# Altium schematic sheet Sheet1.SchDoc(Sheet1)
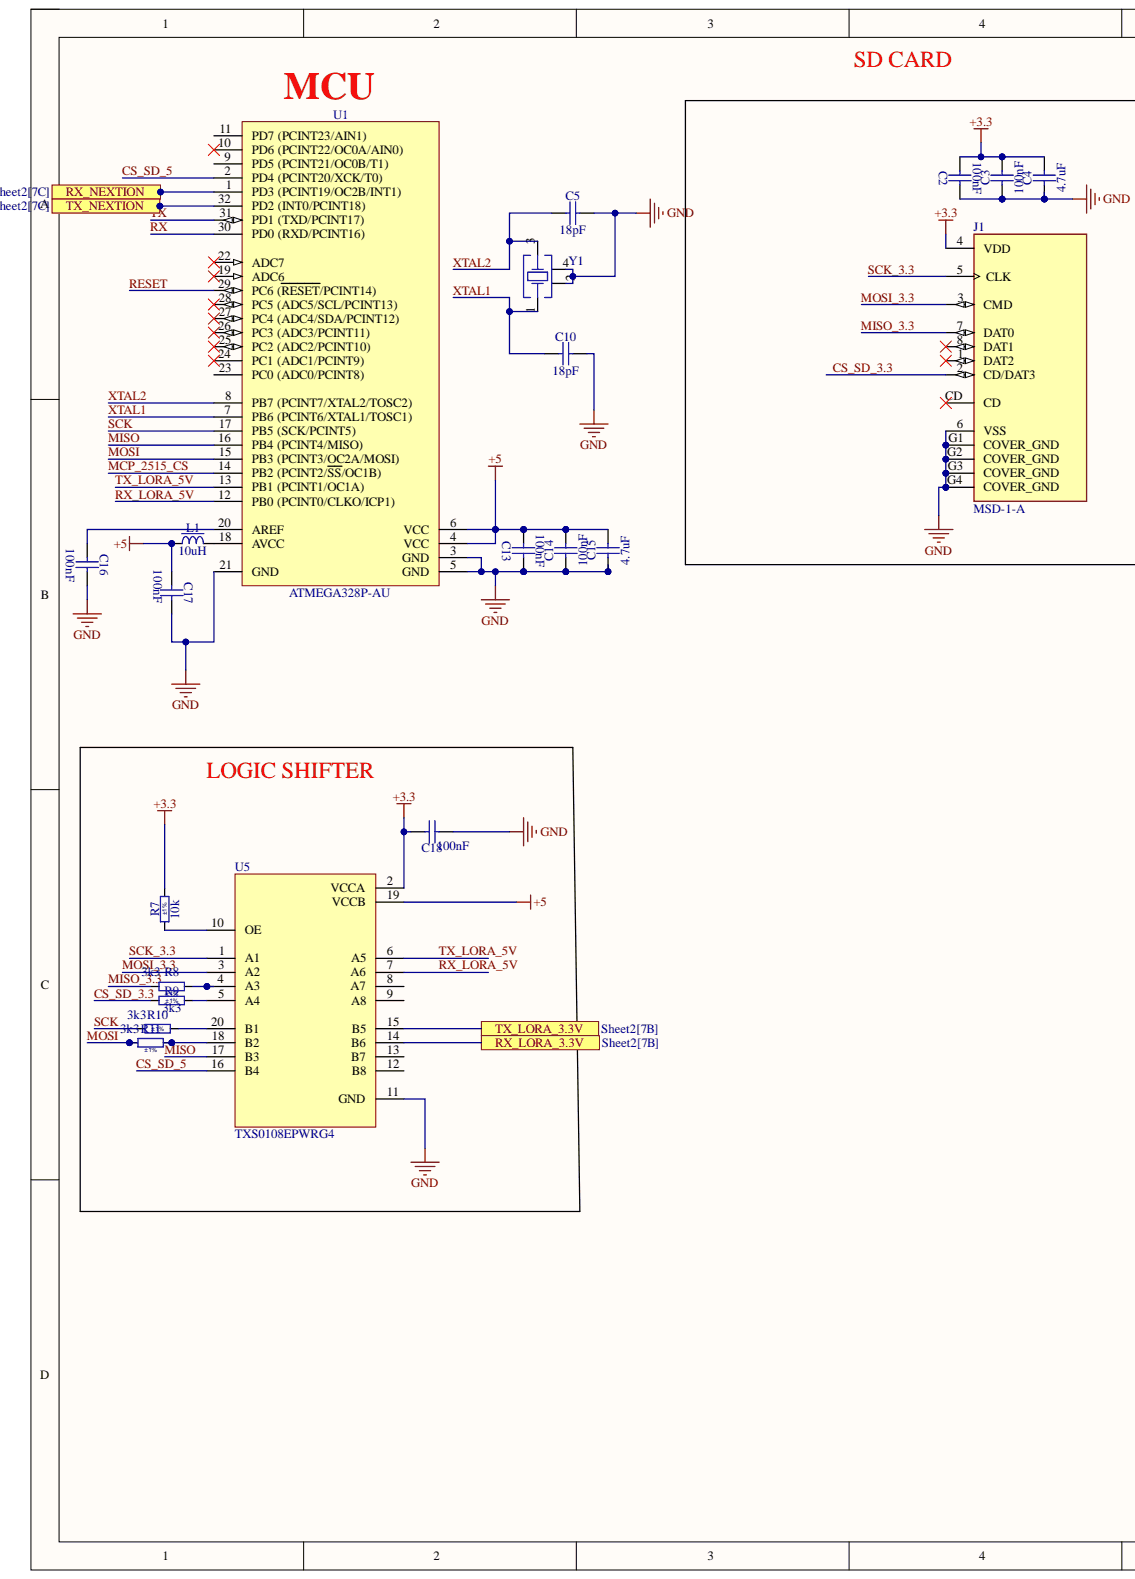
[diagram p0: Sheet1.SchDoc, left]
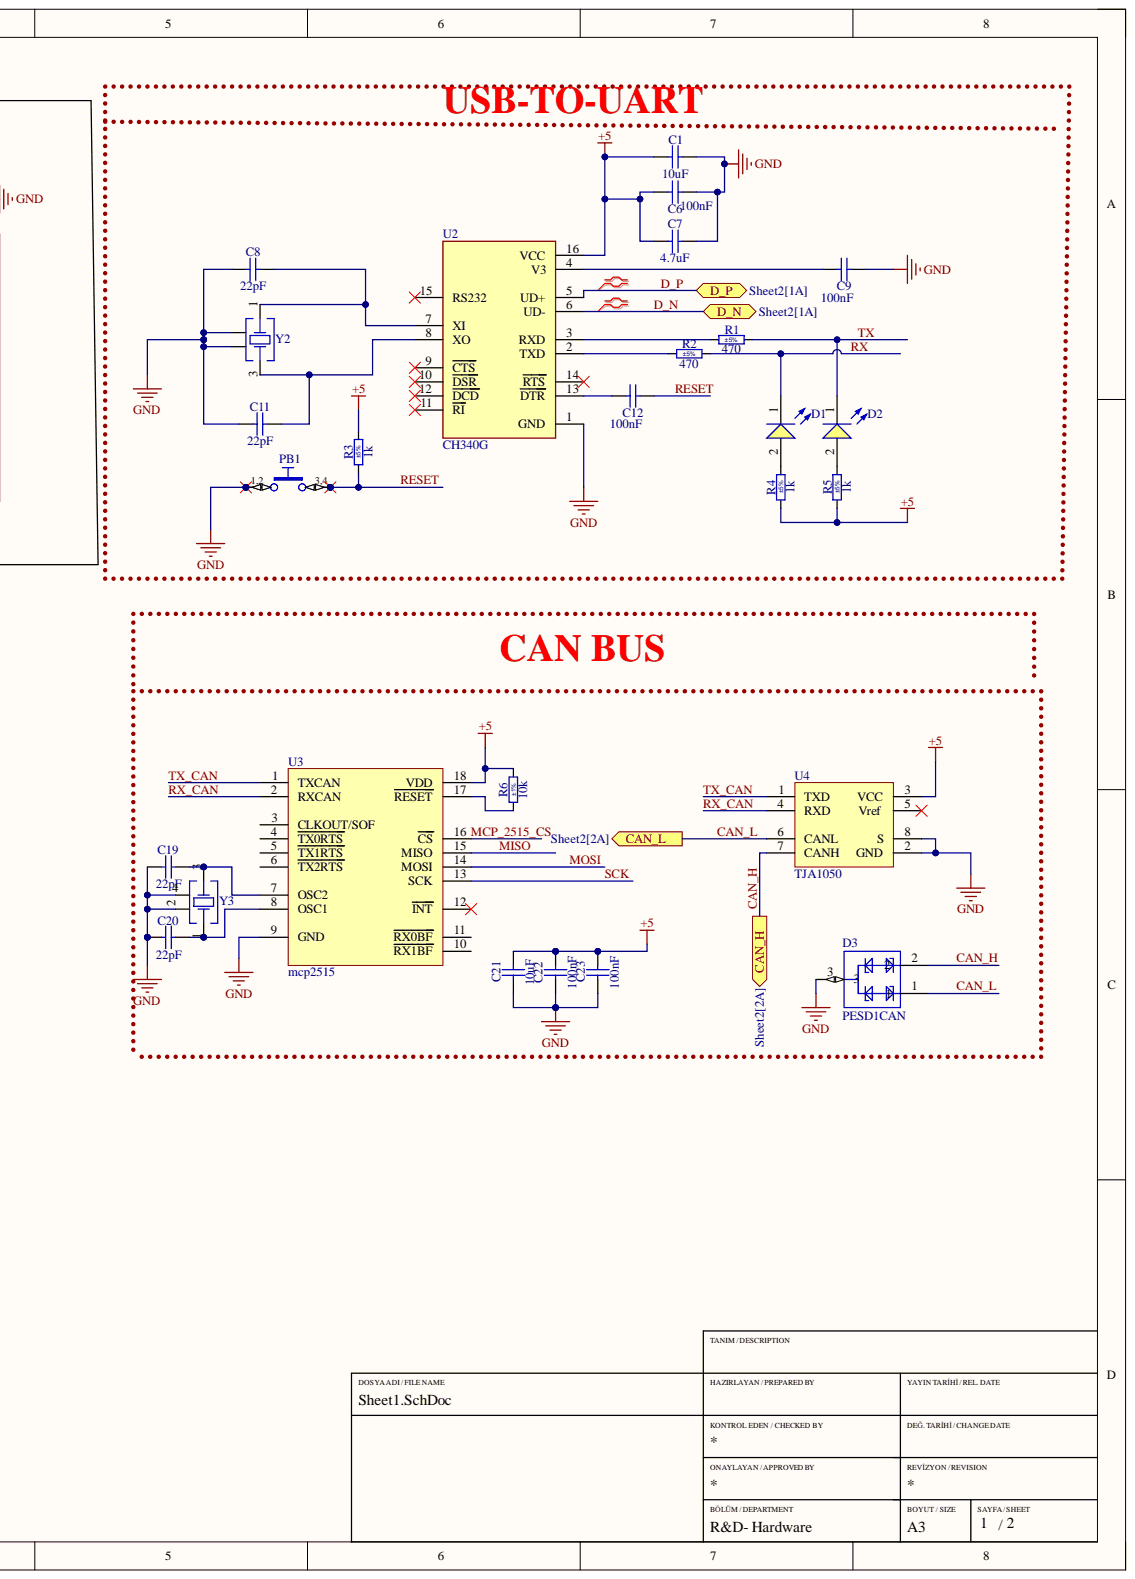
[diagram p1: Sheet1.SchDoc, right]

## Parts
C1  10uF  [CAPC2012X135N]
C2  100nF  [CAPC2012X135N]
C3  100nF  [CAPC2012X135N]
C4  4.7uF  [CAPC2012X135N]
C5  18pF  [CAPC2012X135N]
C6  100nF  [CAPC2012X135N]
C7  4.7uF  [CAPC2012X135N]
C8  22pF  [CAPC2012X135N]
C9  100nF  [CAPC2012X135N]
C10  18pF  [CAPC2012X135N]
C11  22pF  [CAPC2012X135N]
C12  100nF  [CAPC2012X135N]
C13  100nF  [CAPC2012X135N]
C14  100nF  [CAPC2012X135N]
C15  4.7uF  [CAPC2012X135N]
C16  100nF  [CAPC2012X135N]
C17  100nF  [CAPC2012X135N]
C18  100nF  [CAPC2012X135N]
C19  22pF  [CAPC2012X135N]
C20  22pF  [CAPC2012X135N]
C21  10uF  [CAPC2012X135N]
C22  100nF  [CAPC2012X135N]
C23  100nF  [CAPC2012X135N]
D1  Yellow, 2V, 140°  [WL-SMCW_0805_150080xx75000]  (value not drawn)
D2  Yellow, 2V, 140°  [WL-SMCW_0805_150080xx75000]  (value not drawn)
D3  PESD1CAN  [SOT23-3]
J1  MSD-1-A  [CUI_MSD-1-A]
L1  10uH  [INDC2014X145N]
PB1  Push Button  [PB_TYCO_FSM2JSMA]  (value not drawn)
R1  470  Tolerance: ±5%  [RESC2012X60N]
R2  470  Tolerance: ±5%  [RESC2012X60N]
R3  1k  Tolerance: ±5%  [RESC2012X70N]
R4  1k  Tolerance: ±5%  [RESC2012X60N]
R5  1k  Tolerance: ±5%  [RESC2012X60N]
R6  10k  Tolerance: ±1%  [RESC2012X60N]
R7  10k  Tolerance: ±1%  [RESC2012X60N]
R8  3k3  Tolerance: ±1%  [RESC2012X60N]
R9  3k3  Tolerance: ±1%  [RESC2012X60N]
R10  3k3  Tolerance: ±1%  [RESC2012X60N]
R11  3k3  Tolerance: ±1%  [RESC2012X60N]
U1  ATMEGA328P-AU  [QFP80P900X900X120-32N]
U2  CH340G  [SOIC-16]
U3  mcp2515  [SOIC-18 - duplicate]
U4  TJA1050  [SOIC-8]
U5  TXS0108EPWRG4  [SOP65P640X120-20N]
Y1  Load Capacitance: 18pF  [WE-XTAL_CFPX-104]  (hidden comment: 8MHz)
Y2  12MHz  [WE-XTAL_CFPX-104]  (value not drawn)
Y3  8MHz  [WE-XTAL_CFPX-104]  (value not drawn)

## Ports
CAN_H, CAN_L, D_N, D_P, RX_LORA_3.3V, RX_NEXTION, TX_LORA_3.3V, TX_NEXTION

## Nets
+3.3: C2.1 C3.2 C4.2 C18.1 J1.4(VDD) R7.2 U5.2(VCCA)
+5: C1.1 C6.1 C7.1 C13.1 C14.2 C15.2 C17.1 C21.2 C22.2 C23.2 L1.1 R3.2 R4.1 R5.1 R6.2 U1.4(VCC) U1.6(VCC) U2.16(VCC) U3.18(VDD) U4.3(VCC) U5.19(VCCB)
GND: C1.2 C2.2 C3.1 C4.1 C5.2 C6.2 C7.2 C8.1 C9.2 C10.2 C11.1 C13.2 C14.1 C15.1 C16.2 C17.2 C18.2 C19.1 C20.1 C21.1 C22.1 C23.1 D3.3 J1.6(VSS) J1.G1(COVER_GND) J1.G2(COVER_GND) J1.G3(COVER_GND) J1.G4(COVER_GND) PB1.1 PB1.2 U1.3(GND) U1.5(GND) U1.21(GND) U2.1(GND) U3.9(GND) U4.2(GND) U4.8(S) U5.11(GND) Y1.2 Y1.4 Y2.2 Y2.4 Y3.2 Y3.4
CAN_H: D3.2 U4.7(CANH) ; port CAN_H
CAN_L: D3.1 U4.6(CANL) ; port CAN_L
CS_SD_3.3: J1.2(CD/DAT3) R9.1
CS_SD_5: U1.2(PD4_(PCINT20/XCK/T0)) U5.16(B4)
D_N: U2.6(UD-) ; port D_N
D_P: U2.5(UD+) ; port D_P
MCP_2515_CS: U1.14(PB2_(PCINT2/SS/OC1B)) U3.16(CS)
MISO: U1.16(PB4_(PCINT4/MISO)) U3.15(MISO) U5.17(B3)
MISO_3.3: J1.7(DAT0) R8.1
MOSI: R11.1 U1.15(PB3_(PCINT3/OC2A/MOSI)) U3.14(MOSI)
MOSI_3.3: J1.3(CMD) U5.3(A2)
RESET: C12.2 PB1.3 PB1.4 R3.1 U1.29(PC6_(RESET/PCINT14))
RX: D1.1 R2.2 U1.30(PD0_(RXD/PCINT16))
RX_CAN: U3.2(RXCAN) U4.4(RXD)
RX_LORA_3.3V: U5.14(B6) ; port RX_LORA_3.3V
RX_LORA_5V: U1.12(PB0_(PCINT0/CLKO/ICP1)) U5.7(A6)
RX_NEXTION: U1.1(PD3_(PCINT19/OC2B/INT1)) ; port RX_NEXTION
SCK: R10.1 U1.17(PB5_(SCK/PCINT5)) U3.13(SCK)
SCK_3.3: J1.5(CLK) U5.1(A1)
TX: D2.1 R1.2 U1.31(PD1_(TXD/PCINT17))
TX_CAN: U3.1(TXCAN) U4.1(TXD)
TX_LORA_3.3V: U5.15(B5) ; port TX_LORA_3.3V
TX_LORA_5V: U1.13(PB1_(PCINT1/OC1A)) U5.6(A5)
TX_NEXTION: U1.32(PD2_(INT0/PCINT18)) ; port TX_NEXTION
XTAL1: C10.1 U1.7(PB6_(PCINT6/XTAL1/TOSC1)) Y1.1
XTAL2: C5.1 U1.8(PB7_(PCINT7/XTAL2/TOSC2)) Y1.3
NetC8_2: C8.2 U2.7(XI) Y2.1
NetC9_1: C9.1 U2.4(V3)
NetC11_2: C11.2 U2.8(XO) Y2.3
NetC12_1: C12.1 U2.13(DTR)
NetC16_1: C16.1 U1.20(AREF)
NetC19_2: C19.2 U3.7(OSC2) Y3.3
NetC20_2: C20.2 U3.8(OSC1) Y3.1
NetD1_2: D1.2 R4.2
NetD2_2: D2.2 R5.2
NetL1_2: L1.2 U1.18(AVCC)
NetR1_1: R1.1 U2.3(RXD)
NetR2_1: R2.1 U2.2(TXD)
NetR6_1: R6.1 U3.17(RESET)
NetR7_1: R7.1 U5.10(OE)
NetR8_2: R8.2 U5.4(A3)
NetR9_2: R9.2 U5.5(A4)
NetR10_2: R10.2 U5.20(B1)
NetR11_2: R11.2 U5.18(B2)

Not connected: J1 1(DAT2) 8(DAT1) CD; U1 9(PD5_(PCINT21/OC0B/T1)) 10(PD6_(PCINT22/OC0A/AIN0)) 11(PD7_(PCINT23/AIN1)) 19(ADC6) 22(ADC7) 23(PC0_(ADC0/PCINT8)) 24(PC1_(ADC1/PCINT9)) 25(PC2_(ADC2/PCINT10)) 26(PC3_(ADC3/PCINT11)) 27(PC4_(ADC4/SDA/PCINT12)) 28(PC5_(ADC5/SCL/PCINT13)); U2 9(CTS) 10(DSR) 11(RI) 12(DCD) 14(RTS) 15(RS232); U3 3(CLKOUT/SOF) 4(TX0RTS) 5(TX1RTS) 6(TX2RTS) 10(RX1BF) 11(RX0BF) 12(INT); U4 5(Vref); U5 8(A7) 9(A8) 12(B8) 13(B7)

## Library data of the parts
C1 = CC0805_10UF_16V_10%_X5R: Non-polarised capacitor; Manufacturer: GENERIC; Manufacturer1 Part Number: C0805C106K4PAC; Part Description: SMD Multilayer Chip Ceramic Capacitor; Database Table Name: Capacitors SMD; TC: X5R; Tolerance: ±10%; Voltage: 16V; Case: 0805; Component Kind: Standard; Component Type: Standard; CreateDate: 3.12.2007; HelpURL: \\cern.ch\dfs\Applications\Altium\Datasheets\CC1206_X5R_KEMET.pdf; LatestRevisionDate: 3.12.2007; Library Name: Capacitors.DbLib; Manufacturer1 ComponentHeight: 1.4mm; Manufacturer1 Example: KEMET; Manufacturer Part Number: CC0805_10UF_16V_10%_X5R; Mounted: Yes; Part Number: CC0805_10UF_16V_10%_X5R; Pin Count: 2; Sense: No; SMD: Yes; Socket: No; Status: Preferred; Value: 10uF; Author: CERN DEM JLC
C2 C3 C6 C9 C12-C14 C16-C18 C22 C23 = CC0805_100NF_50V_10%_X7R: Non-polarised capacitor; Manufacturer: GENERIC; Manufacturer1 Part Number: C2012X7R1H104K085; Part Description: SMD Multilayer Chip Ceramic Capacitor; Database Table Name: Capacitors SMD; TC: X7R; Tolerance: ±10%; Voltage: 50V; Case: 0805; Component Kind: Standard; Component Type: Standard; CreateDate: 3.12.2007; HelpURL: \\cern.ch\dfs\Applications\Altium\Datasheets\CC0805_X7R_TDK_C.pdf; LatestRevisionDate: 22.04.2015; Library Name: Capacitors.DbLib; Manufacturer1 ComponentHeight: 1mm; Manufacturer1 Example: TDK; Manufacturer Part Number: CC0805_100NF_50V_10%_X7R; Mounted: Yes; Part Number: CC0805_100NF_50V_10%_X7R; Pin Count: 2; Sense: No; SMD: Yes; Socket: No; Status: None; Value: 100nF; Author: CERN DEM JLC
C4 C7 C15 = CC0805_4.7UF_10V_10%_X5R: Non-polarised capacitor; Manufacturer: GENERIC; Manufacturer1 Part Number: 222224013672; Part Description: SMD Multilayer Chip Ceramic Capacitor; Database Table Name: Capacitors SMD; TC: X5R; Tolerance: ±10%; Voltage: 10V; Case: 0805; Component Kind: Standard; Component Type: Standard; CreateDate: 3.12.2007; HelpURL: \\cern.ch\dfs\Applications\Altium\Datasheets\CC0805_X5R_PHYCOMP_HC.pdf; LatestRevisionDate: 3.12.2007; Library Name: Capacitors.DbLib; Manufacturer1 ComponentHeight: 1.45mm; Manufacturer1 Example: PHYCOMP; Manufacturer Part Number: CC0805_4.7UF_10V_10%_X5R; Mounted: Yes; Part Number: CC0805_4.7UF_10V_10%_X5R; Pin Count: 2; Sense: No; SMD: Yes; Socket: No; Status: Preferred; Value: 4.7uF; Author: CERN DEM JLC
C5 C10 = CC0805_18PF_50V_5%_NP0: Non-polarised capacitor; Manufacturer: GENERIC; Manufacturer1 Part Number: 223886115189; Part Description: SMD Multilayer Chip Ceramic Capacitor; Database Table Name: Capacitors SMD; TC: C0G/NP0; Tolerance: ±5%; Voltage: 50V; Case: 0805; Component Kind: Standard; Component Type: Standard; CreateDate: 3.12.2007; HelpURL: \\cern.ch\dfs\Applications\Altium\Datasheets\CC0805_NP0_PHYCOMP.pdf; LatestRevisionDate: 3.12.2007; Library Name: Capacitors.DbLib; Manufacturer1 ComponentHeight: 1.35mm; Manufacturer1 Example: PHYCOMP; Manufacturer Part Number: CC0805_18PF_50V_5%_NP0; Mounted: Yes; Part Number: CC0805_18PF_50V_5%_NP0; Pin Count: 2; Sense: No; SMD: Yes; Socket: No; Status: Preferred; Value: 18pF; Author: CERN DEM JLC
C8 C11 C19 C20 = CC0805_22PF_50V_5%_NP0: Non-polarised capacitor; Manufacturer: GENERIC; Manufacturer1 Part Number: 223886115229; Part Description: SMD Multilayer Chip Ceramic Capacitor; Database Table Name: Capacitors SMD; TC: C0G/NP0; Tolerance: ±5%; Voltage: 50V; Case: 0805; Component Kind: Standard; Component Type: Standard; CreateDate: 3.12.2007; HelpURL: \\cern.ch\dfs\Applications\Altium\Datasheets\CC0805_NP0_PHYCOMP.pdf; LatestRevisionDate: 3.12.2007; Library Name: Capacitors.DbLib; Manufacturer1 ComponentHeight: 1.35mm; Manufacturer1 Example: PHYCOMP; Manufacturer Part Number: CC0805_22PF_50V_5%_NP0; Mounted: Yes; Part Number: CC0805_22PF_50V_5%_NP0; Pin Count: 2; Sense: No; SMD: Yes; Socket: No; Status: Preferred; Value: 22pF; Author: CERN DEM JLC
C21 = CC0805_10UF_10V_10%_X7R: Non-polarised capacitor; Manufacturer: GENERIC; Manufacturer1 Part Number: GRM21BR71A106KE51L; Part Description: SMD Multilayer Chip Ceramic Capacitor; Database Table Name: Capacitors SMD; TC: X7R; Tolerance: ±10%; Voltage: 10V; Case: 0805; Component Kind: Standard; Component Type: Standard; CreateDate: 30.04.2012; HelpURL: \\cern.ch\dfs\Applications\Altium\Datasheets\CC0805_X7R_MURATA.pdf; LatestRevisionDate: 30.04.2012; Library Name: Capacitors.DbLib; Manufacturer1 ComponentHeight: 1.35mm; Manufacturer1 Example: MURATA; Manufacturer Part Number: CC0805_10UF_10V_10%_X7R; Mounted: Yes; Part Number: CC0805_10UF_10V_10%_X7R; Pin Count: 2; Sense: No; SMD: Yes; Socket: No; Status: Preferred; Value: 10uF; Author: CERN DEM JLC
D1 D2 = 150080YS75000: WL-SMCW Mono-color Chip LED Waterclear, size 0805, Yellow, 2V, 140°; Manufacturer: Wurth Elektronik; Case/Size Code: 0805; Chip Technology: AlInGaP; Database Table Name: LEDs; Dominant Wavelength: 590nm; Luminous Intensity: 120mcd; Peak Wavelength: 595nm; Category: Signal LEDs; Component Kind: Standard; ComponentLink1Description: Website Link; ComponentLink1URL: https://www.we-online.com/redexpert/article/150080YS75000?ad; ComponentLink2Description: Datasheet Link; ComponentLink2URL: https://www.we-online.com/redexpert/spec/150080YS75000?ad; Emitting Color: Yellow; Forward Voltage: 2V; Height: 0.7mm; Length: 2mm; Library Name: WE - Optoelectronic Components.DbLib; Manufacturer Part Number: 150080YS75000; Match Code: WL-SMCW; Mount: Surface Mount; Operating Temperature Max: 85°C; Operating Temperature Min: -40°C; Packaging: Tape and Reel; Simulation: 0805_150080YS75000; Viewing Angle: 140°; Width: 1.25mm
D3 = PESD1CAN: PESD1CAN Series 50 V 9.3 pF SMT CAN bus ESD Protection Diode - SOT-323; Manufacturer: Nexperia; Manufacturer Part Number: PESD1CAN-UX; Breakdown Voltage: 25.4V; Clamping Voltage: 35V; Direction: 211Direction; Element Configuration: 5461ElementConfiguration; Leakage Current: 50nA; Number of Bidirectional Channels: 2NUMBER; Number of Channels: 1NUMBER; Peak Pulse Current: 1A; Peak Pulse Power: 150W; Power Line Protection: 5898YesNo; Reverse Standoff Voltage: 24V; Value: 9.3pF; Case/Package: 966Case; Category: ESD and Circuit Protection ICs; Component Kind: Standard; ComponentLink1Description: http://www.farnell.com/datasheets/1738911.pdf?src-supplier=Farnell; ComponentLink1URL: http://www.farnell.com/datasheets/1738911.pdf?src-supplier=Farnell; ComponentLink2Description: https://www.mouser.com/ds/2/302/PESD1CAN-U-1151714.pdf?src-supplier=Mouser; ComponentLink2URL: https://www.mouser.com/ds/2/302/PESD1CAN-U-1151714.pdf?src-supplier=Mouser; ComponentLink3Description: http://www.farnell.com/datasheets/1738911.pdf?src-supplier=Element14; ComponentLink3URL: http://www.farnell.com/datasheets/1738911.pdf?src-supplier=Element14; ComponentLink4Description: https://datasheet.octopart.com/PESD1CAN-UX-Nexperia-datasheet-14741084.pdf?src-supplier=Newark; ComponentLink4URL: https://datasheet.octopart.com/PESD1CAN-UX-Nexperia-datasheet-14741084.pdf?src-supplier=Newark; ComponentLink5Description: http://www.farnell.com/datasheets/1738911.pdf?src-supplier=Newark; ComponentLink5URL: http://www.farnell.com/datasheets/1738911.pdf?src-supplier=Newark; ComponentLink6Description: https://assets.nexperia.com/documents/data-sheet/PESD1CAN-U.pdf?src-supplier=Digikey; ComponentLink6URL: https://assets.nexperia.com/documents/data-sheet/PESD1CAN-U.pdf?src-supplier=Digikey; ComponentLink7Description: https://datasheet.octopart.com/PESD1CAN-UX-Nexperia-datasheet-87844164.pdf?src-supplier=LKR; ComponentLink7URL: https://datasheet.octopart.com/PESD1CAN-UX-Nexperia-datasheet-87844164.pdf?src-supplier=LKR; Contact Plating: 154ConductorMaterial; Depth: 1.35mm; Height: 1.1mm; Lead Free: 5697LeadFree; Length: 2.2mm; Max Operating Temperature: 150°C; Min Breakdown Voltage: 25.4V; Min Operating Temperature: -55°C; Mounting Technology: 8Mount; Operating Supply Voltage: 35V; Packaging: 13Packaging; Pins: 70NUMBER; Polarity: 211Direction; Pricing: 1=0.31, 10=0.213, 100=0.133, 500=0.108, 1000=0.079 (USD); Radiation Hardening: 5898YesNo; RoHS: No; Stock: 431591; Supplier: Digikey; Supplier Part Number: 1727-1306-1-ND; Termination: 4890ConnectorType; Working Voltage: 24V; Library Name: iku_mesut.IntLib
J1 = MSD-1-A: Micro SD Card Connector, 9 Positions, Connector and Ejector, Push In, Auto Eject Out, Surface Mount, 1.8 mm Height Above Board, Gold Flash; MF: Same Sky; Check_prices: https://www.snapeda.com/parts/MSD-1-A/Same+Sky/view-part/?ref=eda; Component Kind: Standard; MP: MSD-1-A; Package: None; SnapEDA_Link: https://www.snapeda.com/parts/MSD-1-A/Same+Sky/view-part/?ref=snap; Library Name: iku_mesut.IntLib
L1 = IND0805_10NH_5%_EPCOS_B82498B: Inductor With Core; Manufacturer: EPCOS; Manufacturer Part Number: B82498B3100J; Part Description: SMT Inductor SIMID Series; Part Number: IND0805_10uH_5%_EPCOS_B82498B; Database Table Name: Inductors SMD; Power: 700mA; Resistance: 0.03R; Tolerance: ±5%; Case: 0805; Component Kind: Standard; Component Type: Standard; ComponentHeight: 1.45mm; CreateDate: 11.02.2008; HelpURL: \\cern.ch\dfs\Applications\Altium\Datasheets\IND0805_EPCOS_B82498B.pdf; LatestRevisionDate: 26.06.2008; Library Name: Inductors & Transformers.DbLib; Mounted: Yes; Pin Count: 2; Sense: No; SMD: Yes; Socket: No; Status: Preferred; Value: 10uH; Author: CERN DEM JLC
PB1 = PB_TYCO_FSM2JSMA: Push-Button Switch SPST; Manufacturer: TYCO ELECTRONICS; Manufacturer Part Number: FSM2JSMA or 2-1437565-7; Part Description: 12VDC 50mA SPST Tactile Push-Button Switch; Database Table Name: Switches; PressFit: No; Component Kind: Standard; Component Type: Standard; ComponentHeight: 4.59mm; CreateDate: 8.09.2008; Family: Push Button; HelpURL: \\cern.ch\dfs\Applications\Altium\Datasheets\PB_TYCO_FSM2JSMA.pdf; LatestRevisionDate: 21.06.2012; Library Name: Eletro-mechanical.DbLib; Mounted: Yes; PackageDescription: Body 6mm x 6mm, Surface Mount Tactile Switches, TYCO ALCOSWITCH FSMJSMA Series; Part Number: PB_TYCO_FSM2JSMA; Pin Count: 4; Sense: No; SMD: Yes; Socket: No; Status: None; Author: CERN DEM JLC
R1 R2 = R0805_470R_1%_0.125W_100PPM: Resistor - 1%; Manufacturer: GENERIC; Manufacturer1 Part Number: NRC10F4700TRF; Part Description: General Purpose Thick Film Chip Resistor; Database Table Name: Resistors SMD; Power: 0.125W; PressFit: No; TC: ±100ppm/°C; Case: 0805; Component Kind: Standard; Component Type: Standard; CreateDate: 3.12.2007; HelpURL: \\cern.ch\dfs\Applications\Altium\Datasheets\R0805_NIC_NRC.pdf; LatestRevisionDate: 13.03.2008; Library Name: Resistors.DbLib; Manufacturer1 ComponentHeight: 0.6mm; Manufacturer1 Example: NIC COMPONENT; Manufacturer Part Number: R0805_470R_1%_0.125W_100PPM; Mounted: Yes; Part Number: R0805_470R_1%_0.125W_100PPM; Pin Count: 2; Sense: No; SMD: Yes; Socket: No; Status: Preferred; Value: 470; Author: CERN DEM JLC
R3 = R0805_1K_1%_0.5W_100PPM_PANASONIC_ERJP: Resistor - 1%; Manufacturer Part Number: ERJP06F1001V; Part Description: 400V, Anti-Surge Thick Film Chip Resistor; Database Table Name: Resistors SMD; Power: 0.5W; PressFit: No; TC: ±100ppm/°C; Voltage: 400V; Case: 0805; Component Kind: Standard; Component Type: Standard; ComponentHeight: 0.7mm; CreateDate: 8.01.2018; HelpURL: \\cern.ch\dfs\Applications\Altium\Datasheets\R0805_PANASONIC_ERJP06.pdf; LatestRevisionDate: 8.01.2018; Library Name: Resistors.DbLib; Manufacturer: PANASONIC; Mounted: Yes; Part Number: R0805_1K_1%_0.5W_100PPM_PANASONIC_ERJP; Pin Count: 2; Sense: No; SMD: Yes; Socket: No; Status: None; Value: 1k; Author: CERN DEM MR
R4 R5 = R0805_1K_0.1%_0.125W_25PPM: Resistor - 5%; Manufacturer: GENERIC; Manufacturer1 Part Number: ERA6AEB102V; Part Description: Thin Film Chip Resistor; Part Number: R0805_1K_5%_0.125W_25PPM; Database Table Name: Resistors SMD; Power: 0.125W; PressFit: No; TC: ±25ppm; Case: 0805; Component Kind: Standard; Component Type: Standard; CreateDate: 18.10.2010; HelpURL: \\cern.ch\dfs\Applications\Altium\Datasheets\R0805_PANASONIC_ERA.pdf; LatestRevisionDate: 18.10.2010; Library Name: Resistors.DbLib; Manufacturer1 ComponentHeight: 0.6mm; Manufacturer1 Example: PANASONIC; Manufacturer Part Number: R0805_1K_0.1%_0.125W_25PPM; Mounted: Yes; Pin Count: 2; Sense: No; SMD: Yes; Socket: No; Status: Not Recommended; Value: 1k; Author: CERN DEM JLC
R6 R7 = R0805_10K_1%_0.125W_100PPM: Resistor - 1%; Manufacturer: GENERIC; Manufacturer1 Part Number: NRC10F1002TRF; Part Description: General Purpose Thick Film Chip Resistor; Database Table Name: Resistors SMD; Power: 0.125W; PressFit: No; TC: ±100ppm/°C; Case: 0805; Component Kind: Standard; Component Type: Standard; CreateDate: 3.12.2007; HelpURL: \\cern.ch\dfs\Applications\Altium\Datasheets\R0805_NIC_NRC.pdf; LatestRevisionDate: 13.03.2008; Library Name: Resistors.DbLib; Manufacturer1 ComponentHeight: 0.6mm; Manufacturer1 Example: NIC COMPONENT; Manufacturer Part Number: R0805_10K_1%_0.125W_100PPM; Mounted: Yes; Part Number: R0805_10K_1%_0.125W_100PPM; Pin Count: 2; Sense: No; SMD: Yes; Socket: No; Status: Preferred; Value: 10k; Author: CERN DEM JLC
R8-R11 = R0805_3K3_1%_0.125W_100PPM: Resistor - 1%; Manufacturer: GENERIC; Manufacturer1 Part Number: NRC10F3301TRF; Part Description: General Purpose Thick Film Chip Resistor; Database Table Name: Resistors SMD; Power: 0.125W; PressFit: No; TC: ±100ppm/°C; Case: 0805; Component Kind: Standard; Component Type: Standard; CreateDate: 3.12.2007; HelpURL: \\cern.ch\dfs\Applications\Altium\Datasheets\R0805_NIC_NRC.pdf; LatestRevisionDate: 13.03.2008; Library Name: Resistors.DbLib; Manufacturer1 ComponentHeight: 0.6mm; Manufacturer1 Example: NIC COMPONENT; Manufacturer Part Number: R0805_3K3_1%_0.125W_100PPM; Mounted: Yes; Part Number: R0805_3K3_1%_0.125W_100PPM; Pin Count: 2; Sense: No; SMD: Yes; Socket: No; Status: Preferred; Value: 3k3; Author: CERN DEM JLC
U1 = ATMEGA328P-AU: 8-bit AVR Microcontroller, 32KB Flash, 1KB EEPROM, 2KB SRAM, 32-Pin TQFP; Manufacturer: ATMEL; Part Description: 8-bit AVR Microcontroller, 32KB Flash, 1KB EEPROM, 2KB SRAM, 32-Pin TQFP, Industrial Grade (-40°C to 85°C); Bonding: No; Database Table Name: Logic; PressFit: No; Case: QFP32; Component Kind: Standard; Component Type: Standard; ComponentHeight: 1.2mm; CreateDate: 2.07.2014; Device: ATMEGA328P-AU; HelpURL: \\cern.ch\dfs\Applications\Altium\Datasheets\ATMEGA328P-AU.pdf; LatestRevisionDate: 2.07.2014; Library Name: ICs And Semiconductors.DbLib; Manufacturer Part Number: ATMEGA328P-AU; Mounted: Yes; PackageDescription: QFP, 0.80mm Pitch, Square; 32 Pin, 7.00mm L X 7.00mm W X 1.20mm H body, IPC Medium Density; Part Number: ATMEGA328P-AU; Pin Count: 32; Sense: No; SMD: Yes; Socket: No; Author: CERN DEM JLC
U2 = CH340G: USB Interface Integrated Circuit; MF: WCH; Availability: Not in stock; Check_prices: https://www.snapeda.com/parts/Ch340G/WCH/view-part/?ref=eda; Component Kind: Standard; MP: Ch340G; name: ch340g; Package: None; Price: None; SnapEDA_Link: https://www.snapeda.com/parts/Ch340G/WCH/view-part/?ref=snap; Library Name: iku_mesut.IntLib
U3 = mcp2515: MCP2515 Series 5.5 V 1 Mb/s Stand Alone SPI Interface CAN Controller- SOIC-18; Manufacturer: Microchip; Manufacturer Part Number: MCP2515-E/SO; Category: Interface ICs; Component Kind: Standard; ComponentLink1Description: https://datasheet.ciiva.com/41459/001086058-da-01-en-ic-can-cnt-w-mcp2515-e-so-soic-18-mcp-41459431.pdf?src-supplier=Conrad; ComponentLink1URL: https://datasheet.ciiva.com/41459/001086058-da-01-en-ic-can-cnt-w-mcp2515-e-so-soic-18-mcp-41459431.pdf?src-supplier=Conrad; ComponentLink2Description: https://datasheet.ciiva.com/1696/21801g-1696575.pdf?src-supplier=Digikey; ComponentLink2URL: https://datasheet.ciiva.com/1696/21801g-1696575.pdf?src-supplier=Digikey; ComponentLink3Description: https://datasheet.ciiva.com/16454/filehandler-aspxddocname-en011733-16454239.pdf?src-supplier=Future+Electronics; ComponentLink3URL: https://datasheet.ciiva.com/16454/filehandler-aspxddocname-en011733-16454239.pdf?src-supplier=Future+Electronics; ComponentLink4Description: https://datasheet.ciiva.com/1495/1669372-1495291.pdf?src-supplier=Element14; ComponentLink4URL: https://datasheet.ciiva.com/1495/1669372-1495291.pdf?src-supplier=Element14; ComponentLink5Description: https://datasheet.ciiva.com/1495/1669372-1495080.pdf?src-supplier=Element14; ComponentLink5URL: https://datasheet.ciiva.com/1495/1669372-1495080.pdf?src-supplier=Element14; ComponentLink6Description: https://datasheet.ciiva.com/15150/getdatasheetpartid-423409-15150045.pdf?src-supplier=Verical; ComponentLink6URL: https://datasheet.ciiva.com/15150/getdatasheetpartid-423409-15150045.pdf?src-supplier=Verical; Library Name: IC_library.IntLib; Pricing 1: 1=2.97, 25=2.46, 100=2.3875 (USD); RoHS: Yes; Stock 1: 6189; Supplier 1: Digikey; Supplier Part Number 1: MCP2515-E/SO-ND
U4 = TJA1050: R-PDSO-G8 TJA1050 Tape & Reel (TR) CANbus interface receiver 70mV 0.075mA 4.9mm 1000Mbps; Manufacturer: NXP Semiconductors; Manufacturer Part Number: TJA1050T/CM,118; Category: Interface ICs; Component Kind: Standard; ComponentLink1Description: https://datasheet.ciiva.com/26933/getdatasheetpartid-747091-26933446.pdf?src-supplier=Verical; ComponentLink1URL: https://datasheet.ciiva.com/26933/getdatasheetpartid-747091-26933446.pdf?src-supplier=Verical; ComponentLink2Description: https://datasheet.ciiva.com/18624/tja1050-18624869.pdf?src-supplier=Digikey; ComponentLink2URL: https://datasheet.ciiva.com/18624/tja1050-18624869.pdf?src-supplier=Digikey; ComponentLink3Description: https://datasheet.ciiva.com/24191/tja1050-24191228.pdf?src-supplier=Future+Electronics; ComponentLink3URL: https://datasheet.ciiva.com/24191/tja1050-24191228.pdf?src-supplier=Future+Electronics; ComponentLink4Description: https://datasheet.ciiva.com/29891/tja1050-29891527.pdf?src-supplier=Avnet; ComponentLink4URL: https://datasheet.ciiva.com/29891/tja1050-29891527.pdf?src-supplier=Avnet; Height: 1.75mm; Library Name: IC_library.IntLib; Pricing 1: 1=1.8862, 10=1.6766, 100=1.3673, 250=1.2874, 500=1.1477, 1000=0.994 (USD); RoHS: Yes; Stock 1: 1754; Supplier 1: Arrow Electronics; Supplier Part Number 1: TJA1050T/CM,118
U5 = TXS0108EPWRG4: 8-bit bidirectional voltage-level shifter for open-drain and push-pull application 20-TSSOP -40 to 85; Manufacturer: Texas Instruments; Data Rate: 60Mbps; High Level Output Current: -50mA; Logic Function: Translator; Low Level Output Current: 50mA; Max Supply Voltage: 5.5V; Min Supply Voltage: 1.2V; Number of Bits: 8; Number of Circuits: 1; Output Current: 620uA; Propagation Delay: 4.8ns; Quiescent Current: 3uA; Rise Time: 6.3ns; Supply Current: 8uA; Turn-On Delay Time: 312ns; Availability: In Stock; Case/Package: TSSOP; Category: Voltage Level Shifters; Channel Type: Bidirectional; Check_prices: https://www.snapeda.com/parts/TXS0108EPWRG4/Texas+Instruments/view-part/?ref=eda; Component Kind: Standard; ComponentLink1Description: https://datasheet.ciiva.com/14287/txs0108e-14287280.pdf?src-supplier=Farnell; ComponentLink1URL: https://datasheet.ciiva.com/14287/txs0108e-14287280.pdf?src-supplier=Farnell; ComponentLink2Description: https://datasheet.ciiva.com/28653/1714661-28653429.pdf?src-supplier=Element14; ComponentLink2URL: https://datasheet.ciiva.com/28653/1714661-28653429.pdf?src-supplier=Element14; ComponentLink3Description: https://datasheet.ciiva.com/4834/sces642b-127094-4834401.pdf?src-supplier=Mouser; ComponentLink3URL: https://datasheet.ciiva.com/4834/sces642b-127094-4834401.pdf?src-supplier=Mouser; ComponentLink4Description: https://datasheet.ciiva.com/15096/getdatasheetpartid-322119-15096276.pdf?src-supplier=Verical; ComponentLink4URL: https://datasheet.ciiva.com/15096/getdatasheetpartid-322119-15096276.pdf?src-supplier=Verical; ComponentLink5Description: https://datasheet.ciiva.com/1508/1815244-1508732.pdf?src-supplier=Element14; ComponentLink5URL: https://datasheet.ciiva.com/1508/1815244-1508732.pdf?src-supplier=Element14; ComponentLink6Description: https://datasheet.ciiva.com/1899/txs0108e-1899605.pdf?src-supplier=Digikey; ComponentLink6URL: https://datasheet.ciiva.com/1899/txs0108e-1899605.pdf?src-supplier=Digikey; ComponentLink7Description: https://datasheet.ciiva.com/1899/txs0108e-1899604.pdf?src-supplier=Digikey; ComponentLink7URL: https://datasheet.ciiva.com/1899/txs0108e-1899604.pdf?src-supplier=Digikey; ComponentLink8Description: https://datasheet.ciiva.com/1899/txs0108e-1899606.pdf?src-supplier=Digikey; ComponentLink8URL: https://datasheet.ciiva.com/1899/txs0108e-1899606.pdf?src-supplier=Digikey; ComponentLink9Description: https://datasheet.ciiva.com/14287/txs0108e-14287532.pdf?src-supplier=Avnet; ComponentLink9URL: https://datasheet.ciiva.com/14287/txs0108e-14287532.pdf?src-supplier=Avnet; Lead Free: Lead Free; Manufacturer Part Number: TXS0108EPWR; Max Operating Temperature: 85°C; MF: Texas Instruments; Min Operating Temperature: -40°C; Mounting Technology: Surface Mount; MP: TXS0108EPWRG4; Number of Channels: 8; Number of Inputs: 8; Package: TSSOP-20 Texas Instruments; Package Quantity: 2000; Packaging: Tape and Reel; Pins: 20; Price: None; Pricing: 1=1.2296, 10=0.8875, 25=0.7971, 100=0.5827 (USD); Purchase-URL: https://pricing.snapeda.com/search/part/TXS0108EPWRG4/?ref=eda; Radiation Hardening: No; Reach SVHC Compliant: No; RoHS: Yes; RoHS Compliant: Yes; Series: -; SnapEDA_Link: https://www.snapeda.com/parts/TXS0108EPWRG4/Texas+Instruments/view-part/?ref=snap; Stock: 242; Supplier: Arrow Electronics; Supplier Part Number: TXS0108EPWR; Termination: SMD/SMT; Weight: 0.002716oz; Library Name: iku_mesut.IntLib
Y1 = 830055663: WE-XTAL Quartz Crystal, SMT, CFPX-104, 8MHz, +/-20ppm; Manufacturer: Wurth Elektronik; Database Table Name: Crystals & Oscillators; Frequency Stability: +/-30ppm; Case/Size Code: CFPX-104; Category: Crystals; Component Kind: Standard; ComponentLink1Description: Website Link; ComponentLink1URL: https://www.we-online.com/redexpert/article/830055663?ad; ComponentLink2Description: Datasheet Link; ComponentLink2URL: https://www.we-online.com/redexpert/spec/830055663?ad; Frequency: 8MHz; Frequency Tolerance: +/-20ppm; Height: 1mm; Length: 5mm; Library Name: WE - Passive Components.DbLib; Manufacturer Part Number: 830055663; Match Code: WE-XTAL; Mount: Surface Mount; Operating Temperature Max: 85°C; Operating Temperature Min: -40°C; Packaging: Tape and Reel; Width: 3.2mm
Y2 = 830055999: WE-XTAL Quartz Crystal, SMT, CFPX-104, 12MHz, +/-30ppm; Manufacturer: Wurth Elektronik; Database Table Name: Crystals & Oscillators; Load Capacitance: 18pF; Case/Size Code: CFPX-104; Category: Crystals; Component Kind: Standard; ComponentLink1Description: Website Link; ComponentLink1URL: https://www.we-online.com/redexpert/article/830055999?ad; ComponentLink2Description: Datasheet Link; ComponentLink2URL: https://www.we-online.com/redexpert/spec/830055999?ad; Frequency: 12MHz; Frequency Stability: +/-30ppm; Frequency Tolerance: +/-30ppm; Height: 1mm; Length: 5mm; Library Name: WE - Passive Components.DbLib; Manufacturer Part Number: 830055999; Match Code: WE-XTAL; Mount: Surface Mount; Operating Temperature Max: 85°C; Operating Temperature Min: -40°C; Packaging: Tape and Reel; Width: 3.2mm
Y3 = 830055663: WE-XTAL Quartz Crystal, SMT, CFPX-104, 8MHz, +/-20ppm; Manufacturer: Wurth Elektronik; Database Table Name: Crystals & Oscillators; Frequency Stability: +/-30ppm; Load Capacitance: 18pF; Case/Size Code: CFPX-104; Category: Crystals; Component Kind: Standard; ComponentLink1Description: Website Link; ComponentLink1URL: https://www.we-online.com/redexpert/article/830055663?ad; ComponentLink2Description: Datasheet Link; ComponentLink2URL: https://www.we-online.com/redexpert/spec/830055663?ad; Frequency: 8MHz; Frequency Tolerance: +/-20ppm; Height: 1mm; Length: 5mm; Library Name: WE - Passive Components.DbLib; Manufacturer Part Number: 830055663; Match Code: WE-XTAL; Mount: Surface Mount; Operating Temperature Max: 85°C; Operating Temperature Min: -40°C; Packaging: Tape and Reel; Width: 3.2mm
